# Altium schematic sheet MCU.SchDoc
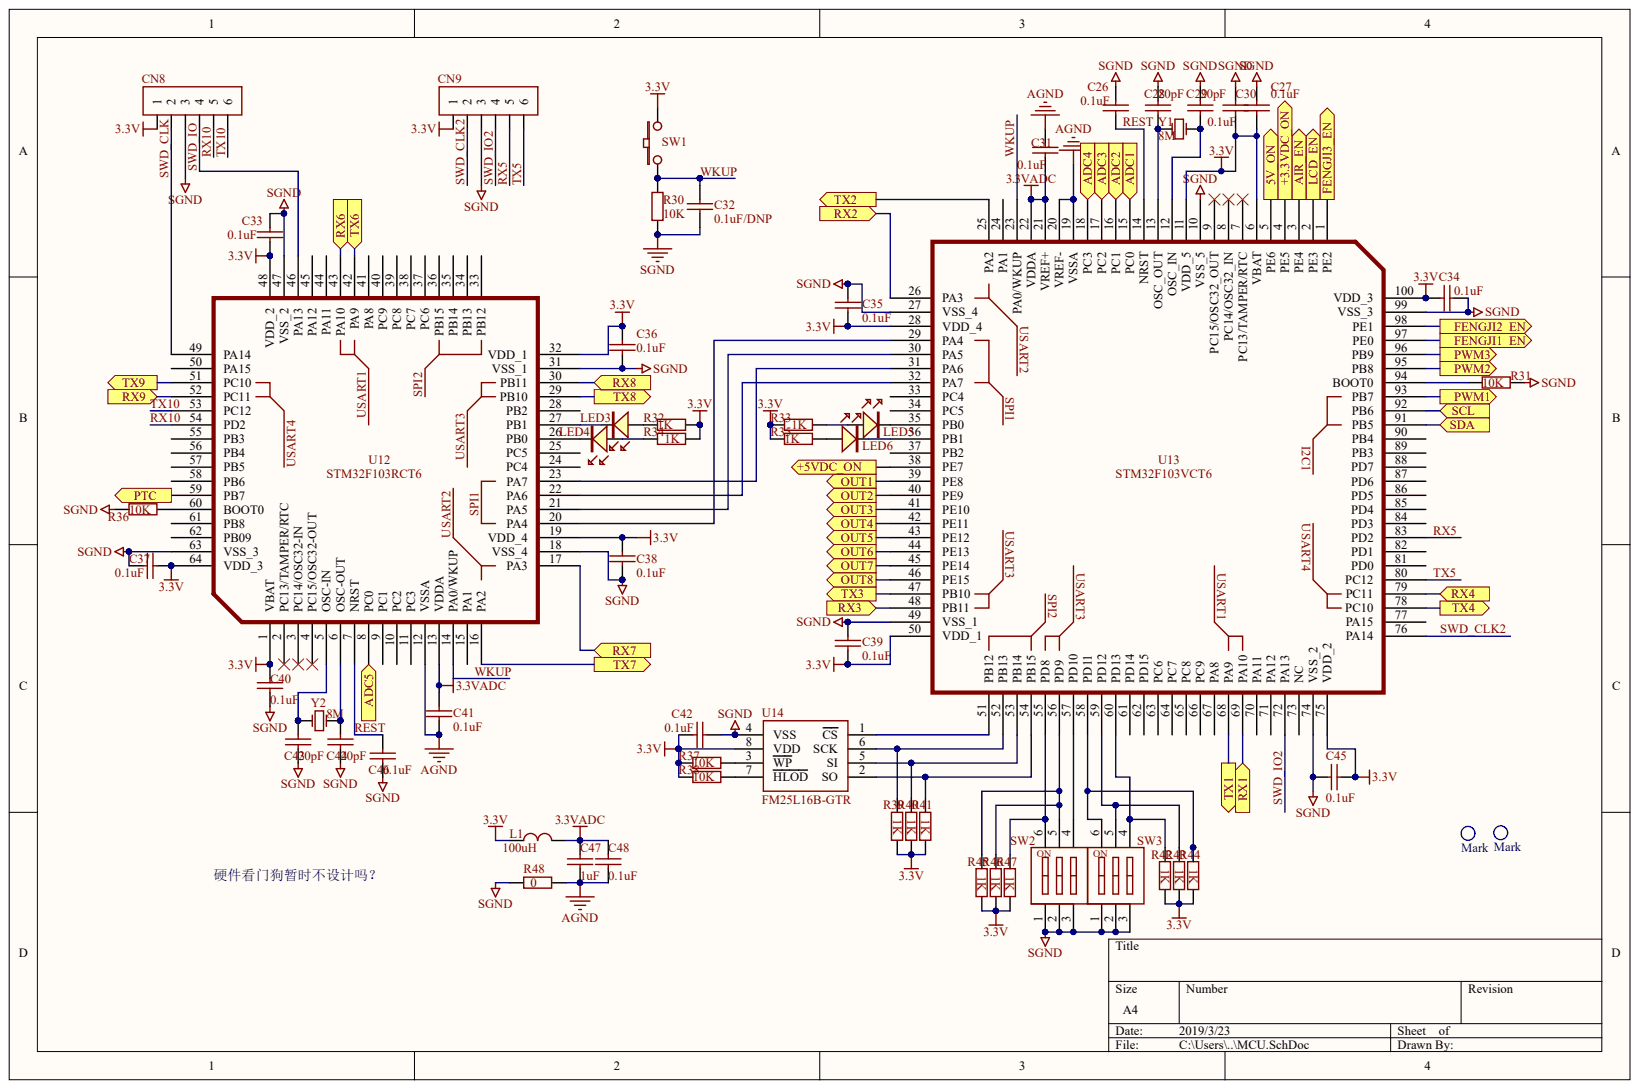
[diagram p0: MCU.SchDoc]

## Parts
C26  0.1uF
C27  0.1uF
C28  20pF
C29  20pF
C30  0.1uF
C31  0.1uF
C32  0.1uF/DNP
C33  0.1uF
C34  0.1uF
C35  0.1uF
C36  0.1uF
C37  0.1uF
C38  0.1uF
C39  0.1uF
C40  0.1uF
C41  0.1uF
C42  0.1uF
C43  20pF
C44  20pF
C45  0.1uF
C46  0.1uF
C47  1uF
C48  0.1uF
CN8  CON6  (value not drawn)
CN9  CON6  (value not drawn)
L1  100uH
LED3  Led  (value not drawn)
LED4  Led  (value not drawn)
LED5  Led  (value not drawn)
LED6  Led  (value not drawn)
M1  Mark
M2  Mark
R30  10K
R31  10K
R32  1K
R33  1K
R34  1K
R35  1K
R36  10K
R37  10K
R38  10K
R39  1K
R40  1K
R41  1K
R42  1K
R43  1K
R44  1K
R45  1K
R46  1K
R47  1K
R48  0
SW1  Button  (value not drawn)
SW2  DSHP02TSGET  (value not drawn)
SW3  DSHP02TSGET  (value not drawn)
U12  STM32F103RCT6
U13  STM32F103VCT6
U14  FM25L16B-GTR
Y1  8M
Y2  8M

## Ports
5V_ON, +3.3VDC_ON, +5VDC_ON, ADC1, ADC2, ADC3, ADC4, ADC5, AIR_EN, FENGJI1_EN, FENGJI2_EN, FENGJI3_EN, LCD_EN, OUT1, OUT2, OUT3, OUT4, OUT5, OUT6, OUT7, OUT8, PTC, PWM1, PWM2, PWM3, RX1, RX2, RX3, RX4, RX6, RX7, RX8, RX9, SCL, SDA, TX1, TX2, TX3, TX4, TX6, TX7, TX8, TX9

## Nets
3.3V: C27.2 C30.2 C33.2 C34.2 C35.2 C36.1 C37.2 C38.1 C39.2 C40.2 C42.1 C45.1 CN8.1 CN9.1 L1.1 R32.2 R33.2 R34.2 R35.2 R37.2 R38.2 R39.1 R40.1 R41.1 R42.1 R43.1 R44.1 R45.1 R46.1 R47.1 SW1.2 U12.1(VBAT) U12.19(VDD_4) U12.32(VDD_1) U12.48(VDD_2) U12.64(VDD_3) U13.6(VBAT) U13.11(VDD_5) U13.28(VDD_4) U13.50(VDD_1) U13.75(VDD_2) U13.100(VDD_3) U14.8(VDD)
3.3VADC: C31.1 C41.2 C47.2 C48.2 L1.2 U12.13(VDDA) U13.21(VREF+) U13.22(VDDA)
AGND: C31.2 C41.1 C47.1 C48.1 R48.1 U12.12(VSSA) U13.19(VSSA) U13.20(VREF-)
SGND: C26.1 C27.1 C28.1 C29.1 C30.1 C32.1 C33.1 C34.1 C35.1 C36.2 C37.1 C38.2 C39.1 C40.1 C42.2 C43.2 C44.2 C45.2 C46.1 CN8.3 CN9.3 R30.2 R31.1 R36.2 R48.2 SW2.1 SW2.2 SW2.3 SW3.1 SW3.2 SW3.3 U12.18(VSS_4) U12.31(VSS_1) U12.47(VSS_2) U12.63(VSS_3) U13.10(VSS_5) U13.27(VSS_4) U13.49(VSS_1) U13.74(VSS_2) U13.99(VSS_3) U14.4(VSS)
5V_ON: U13.5(PE6) ; port 5V_ON
+3.3VDC_ON: U13.4(PE5) ; port +3.3VDC_ON
+5VDC_ON: U13.38(PE7) ; port +5VDC_ON
ADC1: U13.15(PC0) ; port ADC1
ADC2: U13.16(PC1) ; port ADC2
ADC3: U13.17(PC2) ; port ADC3
ADC4: U13.18(PC3) ; port ADC4
ADC5: U12.8(PC0) ; port ADC5
AIR_EN: U13.3(PE4) ; port AIR_EN
FENGJI1_EN: U13.97(PE0) ; port FENGJI1_EN
FENGJI2_EN: U13.98(PE1) ; port FENGJI2_EN
FENGJI3_EN: U13.1(PE2) ; port FENGJI3_EN
LCD_EN: U13.2(PE3) ; port LCD_EN
OUT1: U13.39(PE8) ; port OUT1
OUT2: U13.40(PE9) ; port OUT2
OUT3: U13.41(PE10) ; port OUT3
OUT4: U13.42(PE11) ; port OUT4
OUT5: U13.43(PE12) ; port OUT5
OUT6: U13.44(PE13) ; port OUT6
OUT7: U13.45(PE14) ; port OUT7
OUT8: U13.46(PE15) ; port OUT8
PTC: U12.59(PB7) ; port PTC
PWM1: U13.93(PB7) ; port PWM1
PWM2: U13.95(PB8) ; port PWM2
PWM3: U13.96(PB9) ; port PWM3
REST: C26.2 C46.2 U12.7(NRST) U13.14(NRST)
RX1: U13.69(PA10) ; port RX1
RX2: U13.26(PA3) ; port RX2
RX3: U13.48(PB11) ; port RX3
RX4: U13.79(PC11) ; port RX4
RX5: CN9.5 U13.83(PD2)
RX6: U12.43(PA10) ; port RX6
RX7: U12.17(PA3) ; port RX7
RX8: U12.30(PB11) ; port RX8
RX9: U12.52(PC11) ; port RX9
RX10: CN8.5 U12.54(PD2)
SCL: U13.92(PB6) ; port SCL
SDA: U13.91(PB5) ; port SDA
SWD_CLK: CN8.2 U12.49(PA14)
SWD_CLK2: CN9.2 U13.76(PA14)
SWD_IO: CN8.4 U12.46(PA13)
SWD_IO2: CN9.4 U13.72(PA13)
TX1: U13.68(PA9) ; port TX1
TX2: U13.25(PA2) ; port TX2
TX3: U13.47(PB10) ; port TX3
TX4: U13.78(PC10) ; port TX4
TX5: CN9.6 U13.80(PC12)
TX6: U12.42(PA9) ; port TX6
TX7: U12.16(PA2) ; port TX7
TX8: U12.29(PB10) ; port TX8
TX9: U12.51(PC10) ; port TX9
TX10: CN8.6 U12.53(PC12)
WKUP: C32.2 R30.1 SW1.1 U12.14(PA0/WKUP) U13.23(PA0/WKUP)
NetC28_2: C28.2 U13.13(OSC_OUT) Y1.1
NetC29_2: C29.2 U13.12(OSC_IN) Y1.3
NetC43_1: C43.1 U12.5(OSC-IN) Y2.3
NetC44_1: C44.1 U12.6(OSC-OUT) Y2.1
NetLED3_A: LED3.A R32.1
NetLED3_K: LED3.K U12.27(PB1)
NetLED4_A: LED4.A R34.1
NetLED4_K: LED4.K U12.26(PB0)
NetLED5_A: LED5.A R33.1
NetLED5_K: LED5.K U13.35(PB0)
NetLED6_A: LED6.A R35.1
NetLED6_K: LED6.K U13.36(PB1)
NetR31_2: R31.2 U13.94(BOOT0)
NetR36_1: R36.1 U12.60(BOOT0)
NetR37_1: R37.1 U14.3(WP)
NetR38_1: R38.1 U14.7(HLOD)
NetR39_2: R39.2 U13.52(PB13) U14.6(SCK)
NetR40_2: R40.2 U13.53(PB14) U14.5(SI)
NetR41_2: R41.2 U13.54(PB15) U14.2(SO)
NetR42_2: R42.2 SW3.4 U13.60(PD13)
NetR43_2: R43.2 SW3.5 U13.59(PD12)
NetR44_2: R44.2 SW3.6(ON) U13.58(PD11)
NetR45_2: R45.2 R46.2 SW2.5 U13.56(PD9)
NetR47_2: R47.2 SW2.6(ON) U13.55(PD8)
NetSW2_4: SW2.4 U13.57(PD10)
NetU12_20: U12.20(PA4) U13.29(PA4)
NetU12_21: U12.21(PA5) U13.30(PA5)
NetU12_22: U12.22(PA6) U13.32(PA7)
NetU12_23: U12.23(PA7) U13.31(PA6)
NetU13_51: U13.51(PB12) U14.1(CS)

Not connected: U12 2(PC13/TAMPER/RTC) 3(PC14/OSC32-IN) 4(PC15/OSC32-OUT) 9(PC1) 10(PC2) 11(PC3) 15(PA1) 24(PC4) 25(PC5) 28(PB2) 33(PB12) 34(PB13) 35(PB14) 36(PB15) 37(PC6) 38(PC7) 39(PC8) 40(PC9) 41(PA8) 44(PA11) 45(PA12) 50(PA15) 55(PB3) 56(PB4) 57(PB5) 58(PB6) 61(PB8) 62(PB09); U13 7(PC13/TAMPER/RTC) 8(PC14/OSC32_IN) 9(PC15/OSC32_OUT) 24(PA1) 33(PC4) 34(PC5) 37(PB2) 61(PD14) 62(PD15) 63(PC6) 64(PC7) 65(PC8) 66(PC9) 67(PA8) 70(PA11) 71(PA12) 73(NC) 77(PA15) 81(PD0) 82(PD1) 84(PD3) 85(PD4) 86(PD5) 87(PD6) 88(PD7) 89(PB3) 90(PB4)

## Library data of the parts
C26 C27 C30-C42 C45 C46 C48 = (no symbol name): 三星: CL10B104JB8NNNC; 风华: 0603B104J500NT
C28 C29 C43 C44 = (no symbol name): 风华: 0603CG200J500NT; 三星: CL10C200JB8NNNC
C47 = (no symbol name): 三星: CL10A105KP8NNNC; 风华: 0603X105K100NT
R30-R38 = (no symbol name): 厚生: 0603WAF1002T5E; 风华: RS-03K103FT
R48 = (no symbol name): 厚生: 0603WAF0000T5E; 风华: RS-03K000FT
Y1 Y2 = (no symbol name): 雅晶: 5032-SMD8.000M20PF
CN8 CN9 L1 LED3-LED6 M1 M2 R39-R47 SW1-SW3 U12-U14 = (no symbol name)
  U13: 意法: STM32F103VCT6
